# Altium schematic sheet PowerAndController.SchDoc(PowerAndController)
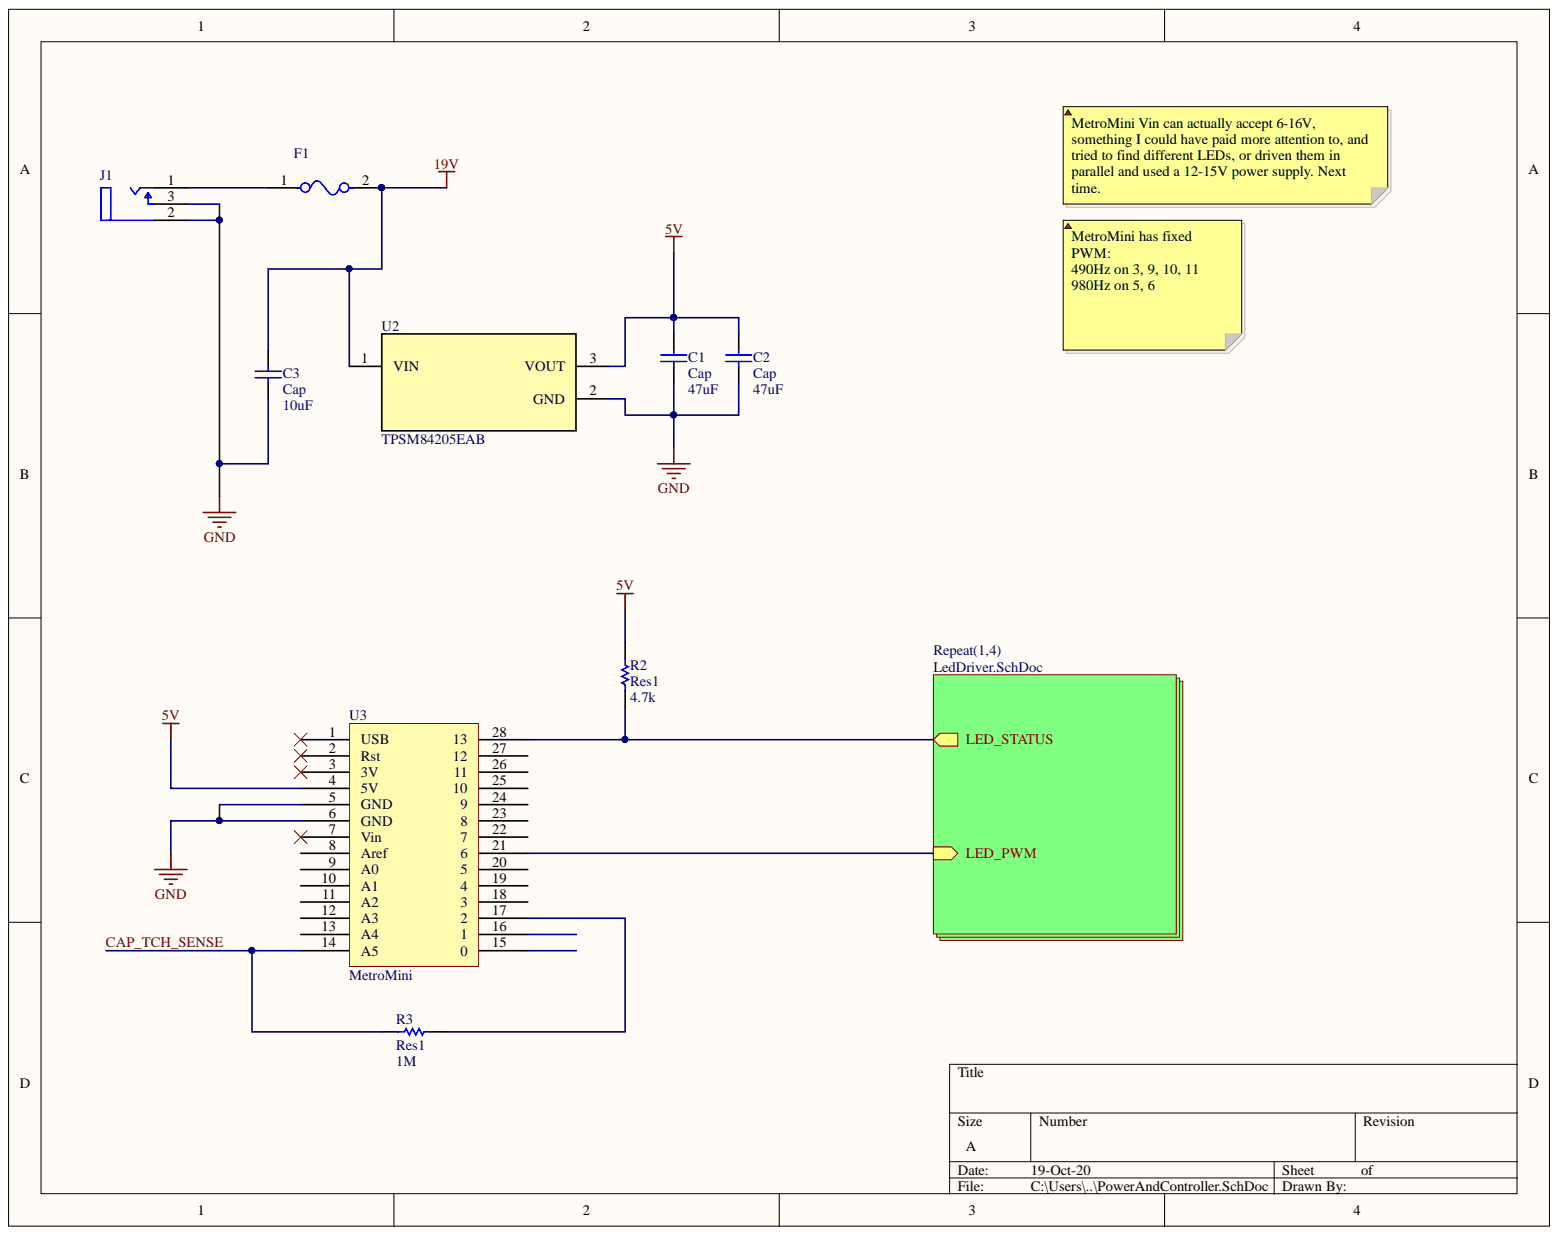
[diagram p0: PowerAndController.SchDoc]

## Parts
C1  Cap  value 47uF  [RAD-0.3]
C2  Cap  value 47uF  [RAD-0.3]
C3  Cap  value 10uF  [RAD-0.3]
F1  (no value)  [FP-0461-MFG]
J1  (no value)  [FP-RASM722PTR13X-MFG]
R2  Res1  value 4.7k  [AXIAL-0.3]
R3  Res1  value 1M  [AXIAL-0.3]
U2  TPSM84205EAB  [EAB0003A]
U3  MetroMini

## Nets
5V: C1.2 C2.2 R2.2 U2.3 U3.4(5V)
19V: C3.2 F1.2 U2.1
GND: C1.1 C2.1 C3.1 J1.2 J1.3 U2.2 U3.5(GND) U3.6(GND)
CAP_TCH_SENSE: R3.1 U3.14(A5)
NetF1_1: F1.1 J1.1
NetR2_1: R2.1 U3.28
NetR3_2: R3.2 U3.17
NetU3_1: U3.1(USB)
NetU3_2: U3.2(Rst)
NetU3_3: U3.3(3V)
NetU3_7: U3.7(Vin)
NetU3_8: U3.8(Aref)
NetU3_9: U3.9(A0)
NetU3_10: U3.10(A1)
NetU3_11: U3.11(A2)
NetU3_12: U3.12(A3)
NetU3_13: U3.13(A4)
NetU3_15: U3.15
NetU3_16: U3.16
NetU3_18: U3.18
NetU3_19: U3.19
NetU3_20: U3.20
NetU3_21: U3.21
NetU3_22: U3.22
NetU3_23: U3.23
NetU3_24: U3.24
NetU3_25: U3.25
NetU3_26: U3.26
NetU3_27: U3.27

## Library data of the parts
C1-C3 = Cap: Capacitor; Simulation: CAP; Signal Integrity: Cap; Published: 8-Jun-2000; PackageReference: RAD-0.3; PackageDescription: Radial Cap, Thru-Hole; 2 Leads; 0.3 in Pin Spacing; Library Name: Miscellaneous Devices.IntLib; LatestRevisionNote: Re-released for DXP Platform.; LatestRevisionDate: 17-Jul-2002; Component Kind: Standard; Publisher: Altium Limited
F1 = CMP-08605-000011-1: FUSE BRD MNT 1.25A 600VAC/80VDC; Manufacturer Part Number: 04611.25ER; Manufacturer: Littelfuse Inc; Voltage Rating: 600V; Value: 0.104Ω; Max Fuse Dc Voltage: 80V; Fuse Interrupt Dc Current: 100A; Fuse Interrupt Ac Current: 60A; Fuse I2T: 16.5A2Sec; Fuse Blow Speed: Slow; Temperature Range Low: -55°C; Temperature Range High: +125°C; Temperature: -55°C to +125°C; RoHS: TRUE; Resistance: 0.104Ω; Package: 0461; Octopart: https://octopart.com/04611.25er-littelfuse-566039; Mouser Description: Surface Mount Fuses 600V 1.25A Telecom Fuse; Max Fuse Ac Voltage: 600V; Library Name: Altium Content Vault; Lead Free: Yes; Ipc Land Pattern Name: CAPC1010312X312; Height: 3.12mm; Fuse Current: 1.25A; Digikey Description: FUSE BRD MNT 1.25A 600VAC/80VDC; Device Class L3: unset; Device Class L2: Fuses; Device Class L1: Circuit Protection; ComponentLink2URL: https://www.littelfuse.com/~/media/electronics/datasheets/fuses/littelfuse_fuse_461_datasheet.pdf.pdf; ComponentLink2Description: Datasheet; Component Kind: Standard; Category: Fuse; Automotive: No
J1 = CMP-47995-000002-1: CONN PWR JACK 2.1X5.5MM SOLDER; Shielding: Unshielded; Gender: Male; Current Rating: 5A; Termination: Solder; Package Quantity: 120; Mount: Surface Mount; Library Name: Altium Content Vault; Housing Material: Thermoplastic; Housing Color: Black; Contact Plating: Tin; Contact Material: Copper Alloy; Connector Type: Jack; Component Kind: Standard; Color: Black; Case/Package: SMD/SMT
R2 R3 = Res1: Resistor; Simulation: RESISTOR; Signal Integrity: Res; Published: 8-Jun-2000; PackageReference: AXIAL-0.3; PackageDescription: Axial Device, Thru-Hole; 2 Leads; 0.3 in Pin Spacing; Library Name: Miscellaneous Devices.IntLib; LatestRevisionNote: Re-released for DXP Platform.; LatestRevisionDate: 17-Jul-2002; Component Kind: Standard; Publisher: Altium Limited
U2 = TPSM84205EAB: No Description Available; PartNumber: TPSM84205EABT; Manufacturer: Texas Instruments; Value: Value; Type: DEV; RefDes: RefDes; Library Name: 2020-09-21_00-30-43.SchLib; Component Kind: Standard; Copyright: Copyright (C) 2018 Accelerated Designs. All rights reserved
U3 = MetroMini: Library Name: Main.SchLib; Component Kind: Standard
